# Altium schematic sheet LEDs.SchDoc(LEDs)
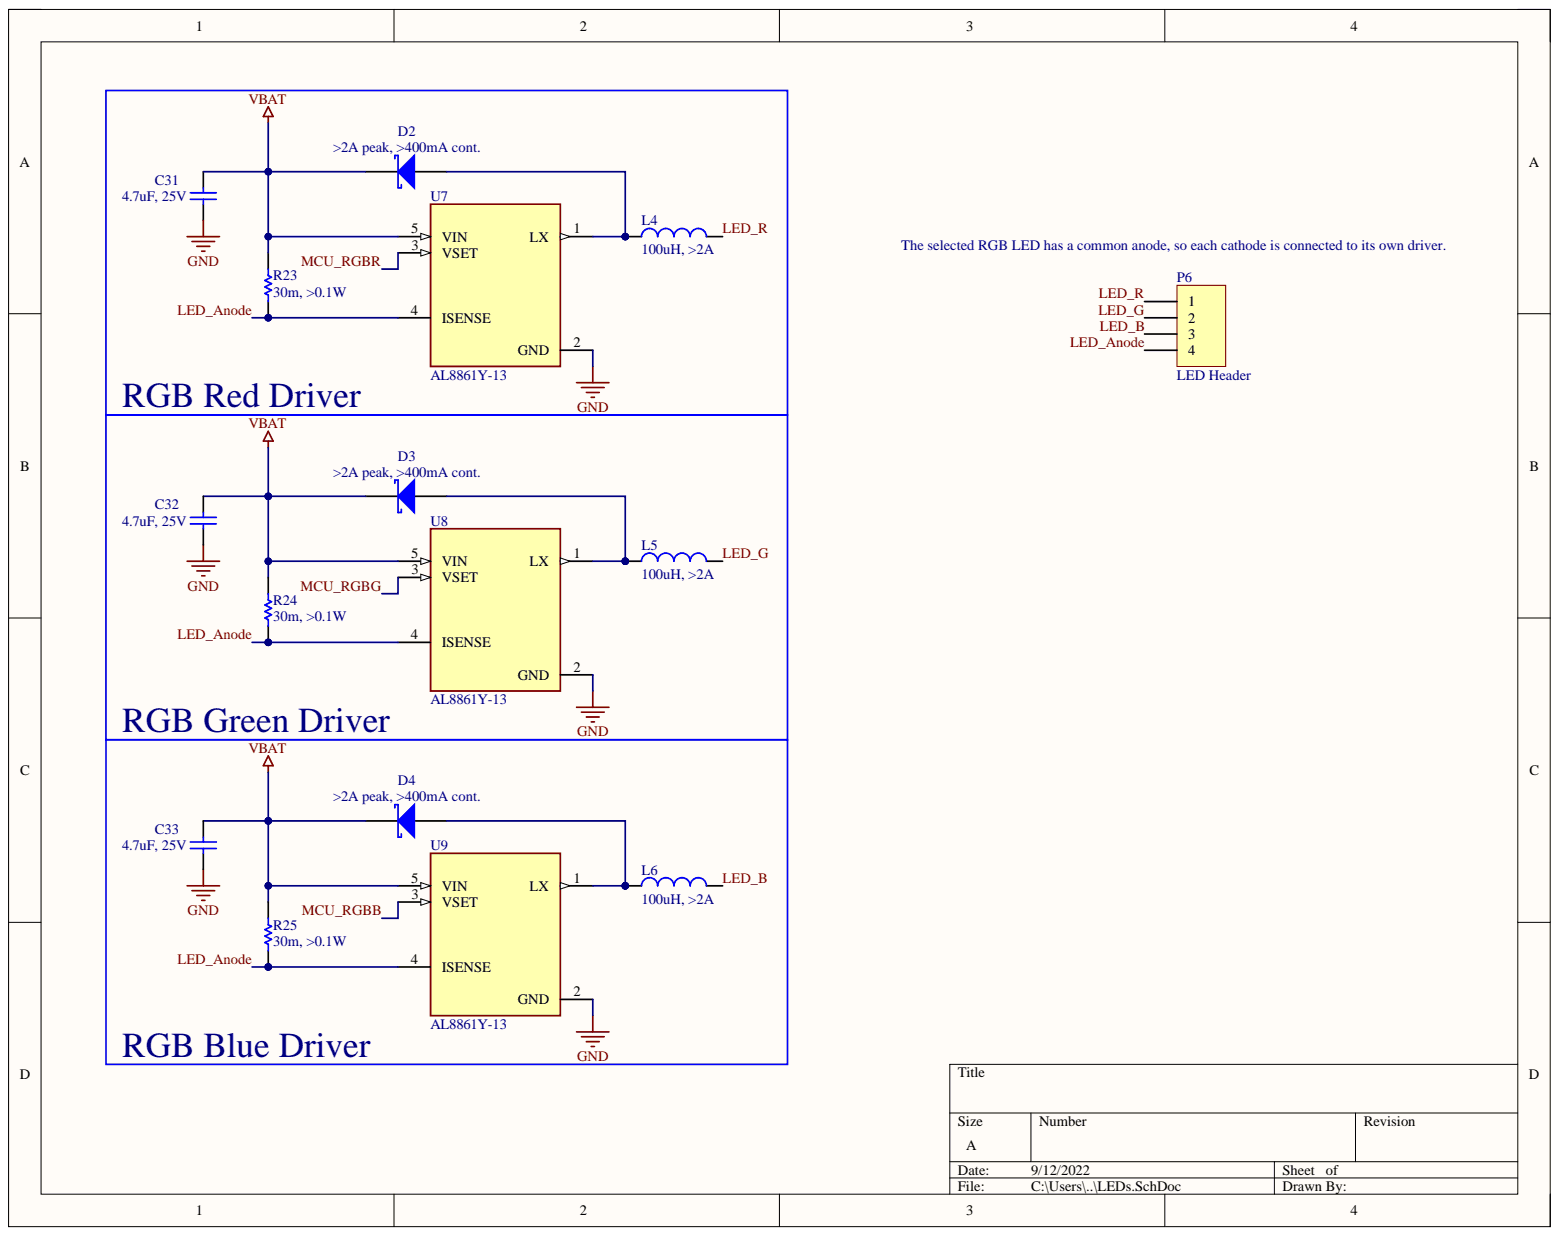
[diagram p0: LEDs.SchDoc]

## Parts
C31  value 4.7uF, 25V  [ANTC1608X90N]  (hidden comment: Capacitor)
C32  value 4.7uF, 25V  [ANTC1608X90N]  (hidden comment: Capacitor)
C33  value 4.7uF, 25V  [ANTC1608X90N]  (hidden comment: Capacitor)
D2  >2A peak, >400mA cont.  [DIO_SS26FL]
D3  >2A peak, >400mA cont.  [DIO_SS26FL]
D4  >2A peak, >400mA cont.  [DIO_SS26FL]
L4  value 100uH, >2A  [INDPM125125X800N]  (hidden comment: Inductor)
L5  value 100uH, >2A  [INDPM125125X800N]  (hidden comment: Inductor)
L6  value 100uH, >2A  [INDPM125125X800N]  (hidden comment: Inductor)
P6  LED Header  [TE_350761-4]
R23  value 30m, >0.1W  [ANTC1608X90N]  (hidden comment: Resistor)
R24  value 30m, >0.1W  [ANTC1608X90N]  (hidden comment: Resistor)
R25  value 30m, >0.1W  [ANTC1608X90N]  (hidden comment: Resistor)
U7  AL8861Y-13  [CONV_AL8861Y-13]
U8  AL8861Y-13  [CONV_AL8861Y-13]
U9  AL8861Y-13  [CONV_AL8861Y-13]

## Nets
GND: C31.1 C32.1 C33.1 U7.2(GND) U8.2(GND) U9.2(GND)
VBAT: C31.2 C32.2 C33.2 D2.2 D3.2 D4.2 R23.1 R24.1 R25.1 U7.5(VIN) U8.5(VIN) U9.5(VIN)
LED_Anode: P6.4 R23.2 R24.2 R25.2 U7.4(ISENSE) U8.4(ISENSE) U9.4(ISENSE)
LED_B: L6.2 P6.3
LED_G: L5.2 P6.2
LED_R: L4.2 P6.1
MCU_RGBB: U9.3(VSET)
MCU_RGBG: U8.3(VSET)
MCU_RGBR: U7.3(VSET)
NetD2_1: D2.1 L4.1 U7.1(LX)
NetD3_1: D3.1 L5.1 U8.1(LX)
NetD4_1: D4.1 L6.1 U9.1(LX)

## Library data of the parts
C31-C33 = Capacitor: SimulationGenericComponent: True; Component Kind: Standard; Description: Capacitor; Library Name: Simulation Generic Components.IntLib; Simulation: C_Capacitor_Default
D2-D4 = Shottky Diode: AF: 1; BV: 0; BV_MAX: 1e99; EG: 1.11; FC: 0.5; IBV: 0.001; IS: 1e-14; MJSW: 0.33; NTUN: 30; SimulationGenericComponent: True; TNOM: 27; XTI: 3; CJO: 0; CJP: 0; Component Kind: Standard; CTA: 0; CTP: 0; Description: Shottky Diode; FCS: 0.5; FV_MAX: 1e99; IKF: 0; IKR: 0; ISR: 1e-14; JSW: 0; JTUN: 0; JTUNSW: 0; KEG: 1; KF: 0; LEVEL: 1; Library Name: Simulation Generic Components.IntLib; N: 1; NBV: 1; NR: 1; NS: 1; PHP: 1; RS: 0; Simulation: diode; TCV: 0; TLEV: 0; TLEVC: 0; TM1: 0; TM2: 0; TPB: 0; TPHP: 0; TRS: 0; TRS2: 0; TT: 0; TTT1: 0; TTT2: 0; VJ: 1; XTITUN: 3
L4-L6 = Inductor: SimulationGenericComponent: True; Component Kind: Standard; Description: Inductor; Library Name: Simulation Generic Components.IntLib; Simulation: L_Inductor_Default
P6 = Header 4: Header, 4-Pin; Component Kind: Standard; LatestRevisionDate: 17-Jul-2002; LatestRevisionNote: Re-released for DXP Platform.; Library Name: Miscellaneous Connectors.IntLib; Signal Integrity: Connector; Publisher: Altium Limited
R23-R25 = Resistor: SimulationGenericComponent: True; TC1: 0; Component Kind: Standard; Description: Resistor; Library Name: Simulation Generic Components.IntLib; Simulation: R_Resistor_Default; TC2: 0
U7-U9 = AL8861Y-13: LED Driver IC 1 Output DC DC Regulator Step-Down _Buck_ Analog, PWM Dimming 1.5A SOT-89-5; MF: Diodes Inc.; Availability: Unavailable; Component Kind: Standard; Library Name: AL8861Y-13.SchLib; MP: AL8861Y-13; Package: SOT-89 Diodes Inc.; Price: None; SnapEDA_Link: https://www.snapeda.com/parts/AL8861Y-13/Diodes%20Inc./view-part/1241445/?ref=snap
